# Altium schematic sheet 005_Power.SchDoc(005_Power) (continued)

## Library data of the parts
C5 = CAP NP_Dup5: cap,2.20uF,+/-10%,25V,X5R,C0805; Manufacturer: TDK; Tolerance: 10%; RK PN: C2012X5R1E225K085AC; Priority: A; option: DNP; C_Voltage: 6.3V; Part Type: C0805; Manufacturer PN: C2012X5R1E225K085AC; Component Kind: Standard; Created by: JJJ
C29 C32 C504 C526 = CAP NP_Dup5: cap,10.00uF,+/-10%,10V,X5R,C0805; Manufacturer: TDK; Tolerance: 10%; RK PN: C2012X5R1A106K085AB; Priority: A; C_Voltage: 6.3V; Part Type: C0805; Manufacturer PN: C2012X5R1A106K085AB; Component Kind: Standard; Created by: JJJ
C30 C33 = CAP NP_Dup5: cap,10.00uF,+/-10%,6.3V,X5R,C0603; Manufacturer: TDK; Tolerance: 10%; RK PN: C1608X5R0J106K080AB; Priority: A; Part Type: C0603; Manufacturer PN: C1608X5R0J106K080AB; Component Kind: Standard; C_Voltage: 6.3V; Created by: JJJ
C31 C34 C529 C538 = CAP NP_Dup5: cap,100.00nF,+/-10%,6.3V,X5R,C0402; Manufacturer: TDK; Tolerance: 10%; RK PN: C1005X5R0J104K050BA; Priority: A; Number: 37; Part Type: C0402; Manufacturer PN: C1005X5R0J104K050BA; Component Kind: Standard; C_Voltage: 6.3V; Created by: JJJ
C104 C505 = CAP NP_Dup5: cap,100.00nF,+/-10%,10V,X5R,C0402; Manufacturer: TDK; Tolerance: 10%; RK PN: C1005X5R1A104K050BA; Priority: A; Number: 37; C_Voltage: 6.3V; Part Type: C0402; Manufacturer PN: C1005X5R1A104K050BA; Component Kind: Standard; Created by: JJJ
C509 C513 C540 = CAP NP_Dup5: cap,1.00uF,+/-10%,10V,X5R,C0402; Manufacturer: TDK; Tolerance: 10%; RK PN: C1005X5R1A105K050BB; Priority: A; C_Voltage: 6.3V; Part Type: C0402; Manufacturer PN: C1005X5R1A105K050BB; Component Kind: Standard; Created by: JJJ
C510 C511 = CAP NP_Dup5: cap,10.00uF,+/-10%,10V,X5R,C0805; Manufacturer: TDK; Tolerance: 10%; RK PN: C2012X5R1A106K085AB; Priority: A; Part Type: C0805; Manufacturer PN: C2012X5R1A106K085AB; Component Kind: Standard; Created by: JJJ
C512 = CAP NP_Dup5: cap,22.00pF,+/-5%,50V,C0G,C0402; Manufacturer: TDK; Tolerance: 5%; RK PN: C1005C0G1H220J050BA; Priority: A; C_Voltage: 6.3V; Part Type: C0402; Manufacturer PN: C1005C0G1H220J050BA; Component Kind: Standard; Created by: JJJ
C514 C516 = CAP NP_Dup5: cap,22.00uF,+/-20%,6.3V,X5R,C0603; Manufacturer: TDK; Tolerance: 20%; RK PN: C1608X5R0J226M080AC; Priority: A; Part Type: C0603; Manufacturer PN: C1608X5R0J226M080AC; Component Kind: Standard; Created by: JJJ
C515 C517 = CAP NP_Dup5: cap,100.00nF,+/-10%,6.3V,X5R,C0402; Manufacturer: TDK; Tolerance: 10%; RK PN: C1005X5R0J104K050BA; Priority: A; Part Type: C0402; Manufacturer PN: C1005X5R0J104K050BA; Component Kind: Standard; Created by: JJJ
C518 = CAP NP_Dup5: Did Not Place.; RK PN: DNP0402; Priority: A; Number: 37; C_Voltage: 6.3V; Part Type: DNP; Manufacturer PN: DNP0402; Component Kind: Standard; Created by: JJJ
C519 C520 = CAP NP_Dup5: cap,100.00nF,+/-10%,10V,X5R,C0402; Manufacturer: TDK; Tolerance: 10%; RK PN: C1005X5R1A104K050BA; Priority: A; Part Type: C0402; Manufacturer PN: C1005X5R1A104K050BA; Component Kind: Standard; Created by: JJJ
C521 C522 = CAP NP_Dup5: cap,100.00pF,+/-10%,50V,C0G,C0402; Manufacturer: TDK; Tolerance: 10%; RK PN: C1005C0G1H101K050BA; Priority: A; Part Type: C0402; Manufacturer PN: C1005C0G1H101K050BA; Component Kind: Standard; Created by: JJJ
C523 C524 = CAP NP_Dup5: cap,10.00nF,+/-10%,25V,X5R,C0402; Manufacturer: TDK; Tolerance: 10%; RK PN: C1005X5R1E103K050BA; Priority: A; Part Type: C0402; Manufacturer PN: C1005X5R1E103K050BA; Component Kind: Standard; Created by: JJJ
C527 = CAP NP_Dup5: cap,100.00pF,+/-10%,50V,C0G,C0402; Manufacturer: TDK; Tolerance: 10%; RK PN: C1005C0G1H101K050BA; Priority: A; Number: 37; C_Voltage: 6.3V; Part Type: C0402; Manufacturer PN: C1005C0G1H101K050BA; Component Kind: Standard; Created by: JJJ
C528 = CAP NP_Dup5: cap,22.00uF,+/-20%,6.3V,X5R,C0603; Manufacturer: TDK; RK PN: C1608X5R0J226M080AC; Priority: A; Tolerance: +/-20%; Part Type: C0603; Manufacturer PN: C1608X5R0J226M080AC; Component Kind: Standard; C_Voltage: 6.3V; Created by: JJJ
C703 = CAP NP_Dup5: cap,100.00nF,+/-10%,25V,X5R,C0402; Manufacturer: TDK; Tolerance: 10%; RK PN: C1005X5R1E104K050BC; Priority: A; Number: 37; C_Voltage: 6.3V; Part Type: C0402; Manufacturer PN: C1005X5R1E104K050BC; Component Kind: Standard; Created by: JJJ
C730 = CAP NP_Dup5: Did Not Place.; RK PN: DNPC0402; Priority: A; Part Type: DNP; Manufacturer PN: DNPC0402; Component Kind: Standard; Created by: JJJ
CE501 = CD5.0/2.5: Component Kind: Standard
CM2 = CM_C: Component Kind: Standard
D1 = Diode: Default Diode; Simulation: DIODE; Signal Integrity: Diode; Published: 8-Jun-2000; PackageVersion: Sep-1996; PackageReference: SMC; PackageDescription: DO-214-AB/SMC; 2 C-Bend Leads; Body 7.9 x 5.9 mm, inc. leads (LxW); Library Name: Miscellaneous Devices.IntLib; LatestRevisionNote: Re-released for DXP Platform.; LatestRevisionDate: 17-Jul-2002; Component Kind: Standard; Code_JEDEC: DO-214-AB; Publisher: Altium Limited
ED5 = DIODE BI_DIR TRIG: 1-Line, Bi-directional, Transient Voltage Suppressors.17.5pF.; Manufacturer: WillSemi(甲먀군랄); Priority: A; RK PN: ESD5451N; Part Type: ESD_TVS; Manufacturer PN: ESD5451N; Component Kind: Standard; Created by: JJJ
J501 = DC_1: Component Kind: Standard
L501 L502 = INDUCTORS OF FERRITE: Power Inductor,2.2uH,0.2,IND_404026; Manufacturer: sunlord; Tolerance: 20%; RK PN: SWPA4026S2R2MT; Resonace frequency: 96M; Priority: A; Isat: 2.10A; Irms: 2A; DC Resistor: 0.04ohm; Part Type: POWER_IND; Manufacturer PN: SWPA4026S2R2MT; Component Kind: Standard; Created by: YTL
L503 = INDUCTORS OF FERRITE: Power Inductor,3.3uH,0.2,IND_303015; Manufacturer: sunlord; Tolerance: 20%; RK PN: SWPA3015S3R3MT; Resonace frequency: 68M; Priority: A; Isat: 1.32A; Irms: 1.36A; DC Resistor: 0.08ohm; Part Type: POWER_IND; Manufacturer PN: SWPA3015S3R3MT; Component Kind: Standard; Created by: YTL
L705 = INDUCTORS OF FERRITE: Manufacturer: sunlord; Priority: A; Part Type: POWER_IND; Component Kind: Standard; Created by: YTL
LED1 = LED_6: Entropic Part Number: 29-1120-0001; 1ST: <1ST>; Component Kind: Standard
LED2 = LED_3_Dup1: Entropic Part Number: 29-1120-0001; Component Kind: Standard
LED4 = LED_4: Entropic Part Number: 29-1120-0001; 1ST: <1ST>; Component Kind: Standard
M501-M504 = MARK: MARK Symble; RK PN: MARK01; Priority: A; Part Type: MARK; Created Date: 20140617; Component Kind: Standard; Created by: CZD
Q503 = NPN: S8050,SOT23; Manufacturer PN: S8050_SOT23; Manufacturer: 닉롯; Vceo: 25V; Priority: A; Pc: 0.3W; Ic: 0.5A; RK PN: S8050; Part Type: NPN; Component Kind: Standard; Created by: JJJ
R3 R125 = RESISTOR_Dup1: 哥棨슌훡롯量,2R,+/-5%,R1210,1/2W.; Manufacturer: YAGEO; Wattage: 1/2W; RK PN: RC1210JR-072RL; Priority: A; Option: DNP; Part Type: 哥棨슌훡롯量; Manufacturer PN: RC1210JR-072RL; Component Kind: Standard; Created by: YTL
R36 R37 = RESISTOR_Dup1: 哥棨슌훡롯量,150K,+/-1%,R0402,1/16W.; Manufacturer: YAGEO; Wattage: 1/16W; Tolerance: 1%; RK PN: RC0402FR-07150KL; Priority: A; Part Type: 哥棨슌훡롯量; Manufacturer PN: RC0402FR-07150KL; Component Kind: Standard; Created by: YTL
R39 R59 = RESISTOR_Dup1: 哥棨슌훡롯量,33K,+/-1%,R0402,1/16W.; Manufacturer: YAGEO; Wattage: 1/16W; Tolerance: 1%; RK PN: RC0402FR-0733KL; Priority: A; Part Type: 哥棨슌훡롯量; Manufacturer PN: RC0402FR-0733KL; Component Kind: Standard; Created by: YTL
R127 = RESISTOR_Dup1: 哥棨슌훡롯量,2R,+/-5%,R1210,1/2W.; Manufacturer: YAGEO; Wattage: 1/2W; Tolerance: 5%; RK PN: RC1210JR-072RL; Priority: A; Option: DNP; Part Type: 哥棨슌훡롯量; Manufacturer PN: RC1210JR-072RL; Component Kind: Standard; Created by: YTL
R502 R515 R2519 R2520 = RESISTOR_Dup1: 哥棨슌훡롯量,510R,+/-5%,R0402,1/16W.; Manufacturer: YAGEO; Wattage: 1/16W; RK PN: RC0402JR-07510RL; Priority: A; Part Type: 哥棨슌훡롯量; Manufacturer PN: RC0402JR-07510RL; Component Kind: Standard; Created by: YTL
R513 R540 R546 R548 = RESISTOR_Dup1: 哥棨슌훡롯量,10K,+/-1%,R0402,1/16W.; Manufacturer: YAGEO; Wattage: 1/16W; RK PN: RC0402FR-0710KL; Priority: A; Part Type: 哥棨슌훡롯量; Manufacturer PN: RC0402FR-0710KL; Component Kind: Standard; Created by: YTL
R521 = RESISTOR_Dup1: 哥棨슌훡롯量,47K,+/-5%,R0402,1/16W.; Manufacturer: YAGEO; Wattage: 1/16W; Tolerance: 5%; RK PN: RC0402JR-0747KL; Priority: A; Part Type: 哥棨슌훡롯量; Manufacturer PN: RC0402JR-0747KL; Component Kind: Standard; Created by: YTL
R528 R705 = RESISTOR_Dup1: 哥棨슌훡롯量,100K,+/-5%,R0402,1/16W.; Manufacturer: YAGEO; Wattage: 1/16W; RK PN: RC0402JR-07100KL; Priority: A; Part Type: 哥棨슌훡롯量; Manufacturer PN: RC0402JR-07100KL; Component Kind: Standard; Created by: YTL
R529 R560 = RESISTOR_Dup1: 哥棨슌훡롯量,10K,+/-5%,R0402,1/16W.; Manufacturer: YAGEO; Wattage: 1/16W; RK PN: RC0402JR-0710KL; Priority: A; Part Type: 哥棨슌훡롯量; Manufacturer PN: RC0402JR-0710KL; Component Kind: Standard; Created by: YTL
R530 R539 R541 = RESISTOR_Dup1: 哥棨슌훡롯量,100K,+/-1%,R0402,1/16W.; Manufacturer: YAGEO; Wattage: 1/16W; RK PN: RC0402FR-07100KL; Priority: A; Part Type: 哥棨슌훡롯量; Manufacturer PN: RC0402FR-07100KL; Component Kind: Standard; Created by: YTL
R531 R550 = RESISTOR_Dup1: 哥棨슌훡롯量,270K,+/-5%,R0402,1/16W.; Manufacturer: YAGEO; Wattage: 1/16W; RK PN: RC0402JR-07270KL; Priority: A; Part Type: 哥棨슌훡롯量; Manufacturer PN: RC0402JR-07270KL; Component Kind: Standard; Created by: YTL
R532 R533 = RESISTOR_Dup1: 哥棨슌훡롯量,100R,+/-5%,R0402,1/16W.; Manufacturer: YAGEO; Wattage: 1/16W; RK PN: RC0402JR-07100RL; Priority: A; Part Type: 哥棨슌훡롯量; Manufacturer PN: RC0402JR-07100RL; Component Kind: Standard; Created by: YTL
R537 = RESISTOR_Dup1: 哥棨슌훡롯量,390K,+/-1%,R0402,1/16W.; Manufacturer: YAGEO; Wattage: 1/16W; RK PN: RC0402FR-07390KL; Priority: A; Part Type: 哥棨슌훡롯量; Manufacturer PN: RC0402FR-07390KL; Component Kind: Standard; Created by: YTL
R538 = RESISTOR_Dup1: 哥棨슌훡롯量,22K,+/-1%,R0402,1/16W.; Manufacturer: YAGEO; Wattage: 1/16W; RK PN: RC0402FR-0722KL; Priority: A; Part Type: 哥棨슌훡롯量; Manufacturer PN: RC0402FR-0722KL; Component Kind: Standard; Created by: YTL
R542 R712 = RESISTOR_Dup1: 哥棨슌훡롯量,30K,+/-1%,R0402,1/16W.; Manufacturer: YAGEO; Wattage: 1/16W; RK PN: RC0402FR-0730KL; Priority: A; Part Type: 哥棨슌훡롯量; Manufacturer PN: RC0402FR-0730KL; Component Kind: Standard; Created by: YTL
R543 = RESISTOR_Dup1: 哥棨슌훡롯量,270K,+/-1%,R0402,1/16W.; Manufacturer: YAGEO; Wattage: 1/16W; RK PN: RC0402FR-07270KL; Priority: A; Part Type: 哥棨슌훡롯量; Manufacturer PN: RC0402FR-07270KL; Component Kind: Standard; Created by: YTL
R544 = RESISTOR_Dup1: 哥棨슌훡롯量,27K,+/-1%,R0402,1/16W.; Manufacturer: YAGEO; Wattage: 1/16W; RK PN: RC0402FR-0727KL; Priority: A; Part Type: 哥棨슌훡롯量; Manufacturer PN: RC0402FR-0727KL; Component Kind: Standard; Created by: YTL
R545 = RESISTOR_Dup1: 哥棨슌훡롯量,196K,+/-1%,R0402,1/16W.; Manufacturer: YAGEO; Wattage: 1/16W; RK PN: RC0402FR-07196KL; Priority: A; Part Type: 哥棨슌훡롯量; Manufacturer PN: RC0402FR-07196KL; Component Kind: Standard; Created by: YTL
R547 R549 = RESISTOR_Dup1: 哥棨슌훡롯量,120K,+/-1%,R0402,1/16W.; Manufacturer: YAGEO; Wattage: 1/16W; RK PN: RC0402FR-07120KL; Priority: A; Part Type: 哥棨슌훡롯量; Manufacturer PN: RC0402FR-07120KL; Component Kind: Standard; Created by: YTL
R552 R558 = RESISTOR_0: Component Kind: Standard
R561 = RESISTOR_Dup1: 哥棨슌훡롯量,0R,+/-5%,R0402,1/16W.; Manufacturer: YAGEO; Wattage: 1/16W; RK PN: RC0402JR-070RL; Priority: A; Part Type: 哥棨슌훡롯量; Manufacturer PN: RC0402JR-070RL; Component Kind: Standard; Created by: YTL
R562 = RESISTOR_Dup1: 哥棨슌훡롯量,0R,+/-5%,R0402,1/16W.; Manufacturer: YAGEO; Wattage: 1/16W; Tolerance: 5%; RK PN: RC0402JR-070RL; Priority: A; Part Type: 哥棨슌훡롯量; Manufacturer PN: RC0402JR-070RL; Component Kind: Standard; Created by: YTL
R711 = RESISTOR_Dup1: 哥棨슌훡롯量,232K,+/-1%,R0402,1/16W.; Manufacturer: YAGEO; Wattage: 1/16W; RK PN: RC0402FR-07232KL; Priority: A; Part Type: 哥棨슌훡롯量; Manufacturer PN: RC0402FR-07232KL; Component Kind: Standard; Created by: YTL
U503 = LDO_TT2102: RK PN: TT2102; Priority: A; Part Type: LDO; Manufacturer PN: TT2102; Component Kind: Standard; Created by: JJJ
U504 U505 = DCDC_SY8088: High Efficiency 5.5V, 2A continuous, 3A peak, 1MHz Synchronous Step Down Regulator; Manufacturer: Silergy?肩텝찾?; RK PN: SY8089AAC; Priority: A; Part Type: DCDC; Manufacturer PN: SY8089AAC; Component Kind: Standard; Created by: JJJ
U506 = DCDC_SY8088: Manufacturer: Silergy?肩텝찾?; RK PN: SY8088AAC; Priority: A; Part Type: DCDC; Manufacturer PN: SY8088AAC; Component Kind: Standard; Created by: JJJ
U509 = Voltage_Detector_CE8809: Ultra-Small Built-In Delay High-Precision Voltage Detector; Manufacturer: Chipower?拱텝匣?; RK PN: CE8809C263MA; Priority: A; Part Type: Voltage_Detectors; Manufacturer PN: CE8809C263MA; Component Kind: Standard; Created by: JJJ
U606 U607 = LDO_AMS1117: Output 800mA LDO.Fixed Voltage 3.3V.; Manufacturer: Advanced Monolithic Systems; RK PN: AMS1117-3.3; Priority: A; Part Type: LDO; Manufacturer PN: AMS1117-3.3; Component Kind: Standard; Created by: JJJ
U703 = DCDC_FR9881: 18V, 2A, 500KHz Synchronous Step-Down DC/DC Converter.; Manufacturer: FitiPower; Priority: A; RK PN: FR9881S6; Part Type: DCDC; Manufacturer PN: FR9881S6; Component Kind: Standard; Created by: JJJ
